# Altium schematic sheet Sheet.SchDoc
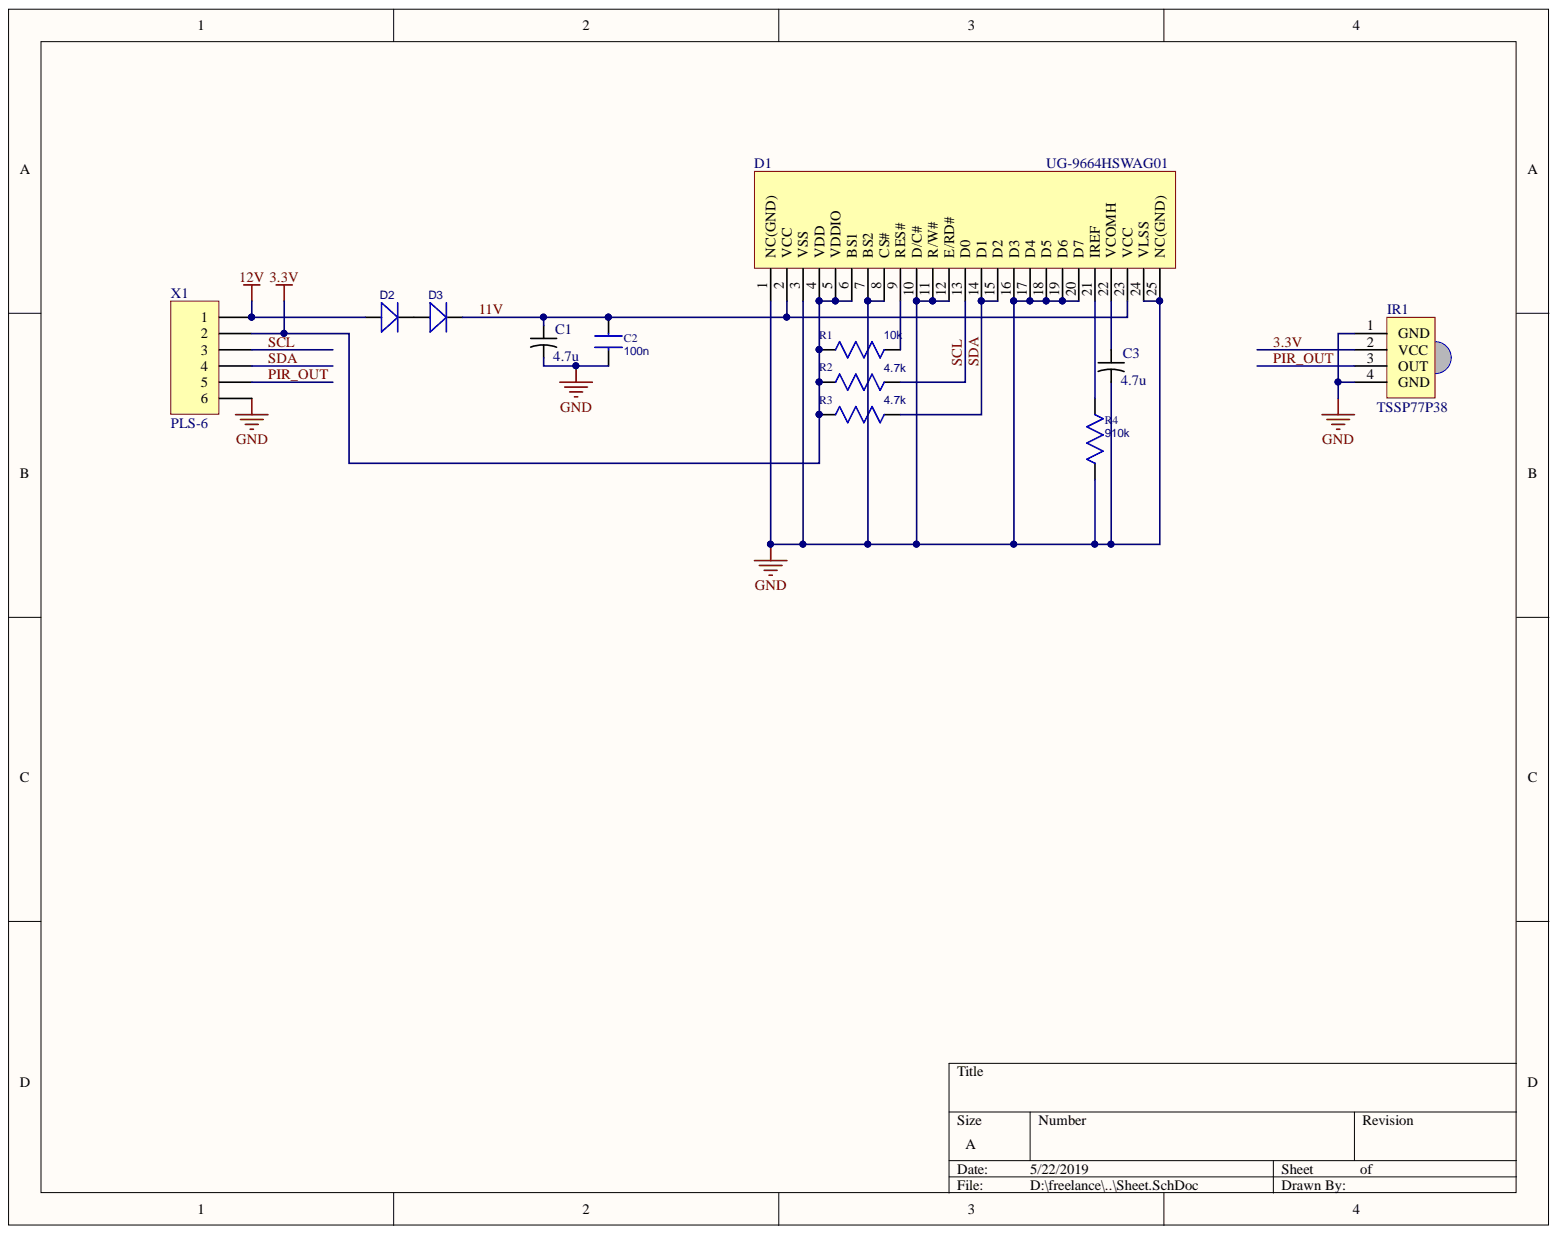
[diagram p0: Sheet.SchDoc]

## Parts
C1  4.7u  [TANTALUM_CAP_SIZE_A]
C2  100n  [C_0603]
C3  4.7u  [TANTALUM_CAP_SIZE_A]
D1  UG-9664HSWAG01  [UG-9664HSWAG01]
D2  D_DO-214AC  [DO-214AC]  (value not drawn)
D3  D_DO-214AC  [DO-214AC]  (value not drawn)
IR1  TSSP77P38
R1  10k  [R_0603]
R2  4.7k  [R_0603]
R3  4.7k  [R_0603]
R4  910k  [R_0603]
X1  PLS-6  [PLS-6-3D]

## Nets
12V: D2.1 X1.1
GND: C1.2 C2.1 C3.2 D1.1(NC(GND)) D1.3(VSS) D1.7(BS2) D1.8(CS#) D1.10(D/C#) D1.11(R/W#) D1.12(E/RD#) D1.16(D3) D1.17(D4) D1.18(D5) D1.19(D6) D1.20(D7) D1.24(VLSS) D1.25(NC(GND)) IR1.1(GND) IR1.4(GND) R4.2 X1.6
3.3V: D1.4(VDD) D1.5(VDDIO) D1.6(BS1) IR1.2(VCC) R1.1 R2.1 R3.1 X1.2
11V: C1.1 C2.2 D1.2(VCC) D1.23(VCC) D3.2
PIR_OUT: IR1.3(OUT) X1.5
SCL: D1.13(D0) R2.2 X1.3
SDA: D1.14 D1.15(D2) R3.2 X1.4
NetC3_1: C3.1 D1.22(VCOMH)
NetD1_9: D1.9(RES#) R1.2
NetD1_21: D1.21(IREF) R4.1
NetD2_2: D2.2 D3.1

## Library data of the parts
C1 C3 = Tantalum Capacitor: Component Kind: Standard; Description: Tantalum Capacitor; Library Name: BublykIntegratedLibrary.IntLib
C2 = C0603: Capacitor; Component Kind: Standard; Library Name: AX_Altium_v2.3.IntLib; Value: 100n
D1 = UG-9664HSWAG01: Display; Component Kind: Standard; Library Name: SmartOutletIntegratedLibrary.IntLib
D2 D3 = D_DO-214AC: Diode; Component Kind: Standard; Library Name: AX_Altium_v2.3.IntLib
IR1 = TSSP77P38: IR Detector for Mid Range Proximity Sensor; Component Kind: Standard; Library Name: BublykIntegratedLibrary.IntLib
R1-R4 = R0603: Resistor; Component Kind: Standard; Library Name: AX_Altium_v2.3.IntLib
  R1: Value: 10k
  R2 R3: Value: 4.7k
  R4: Value: 910k
X1 = PLS-6: Header, 6-Pin; Component Kind: Standard; LatestRevisionDate: 17-Jul-2002; LatestRevisionNote: Re-released for DXP Platform.; Library Name: AX_Altium_v2.3.IntLib; Publisher: Altium Limited
